# Altium schematic sheet Sheet1.SchDoc
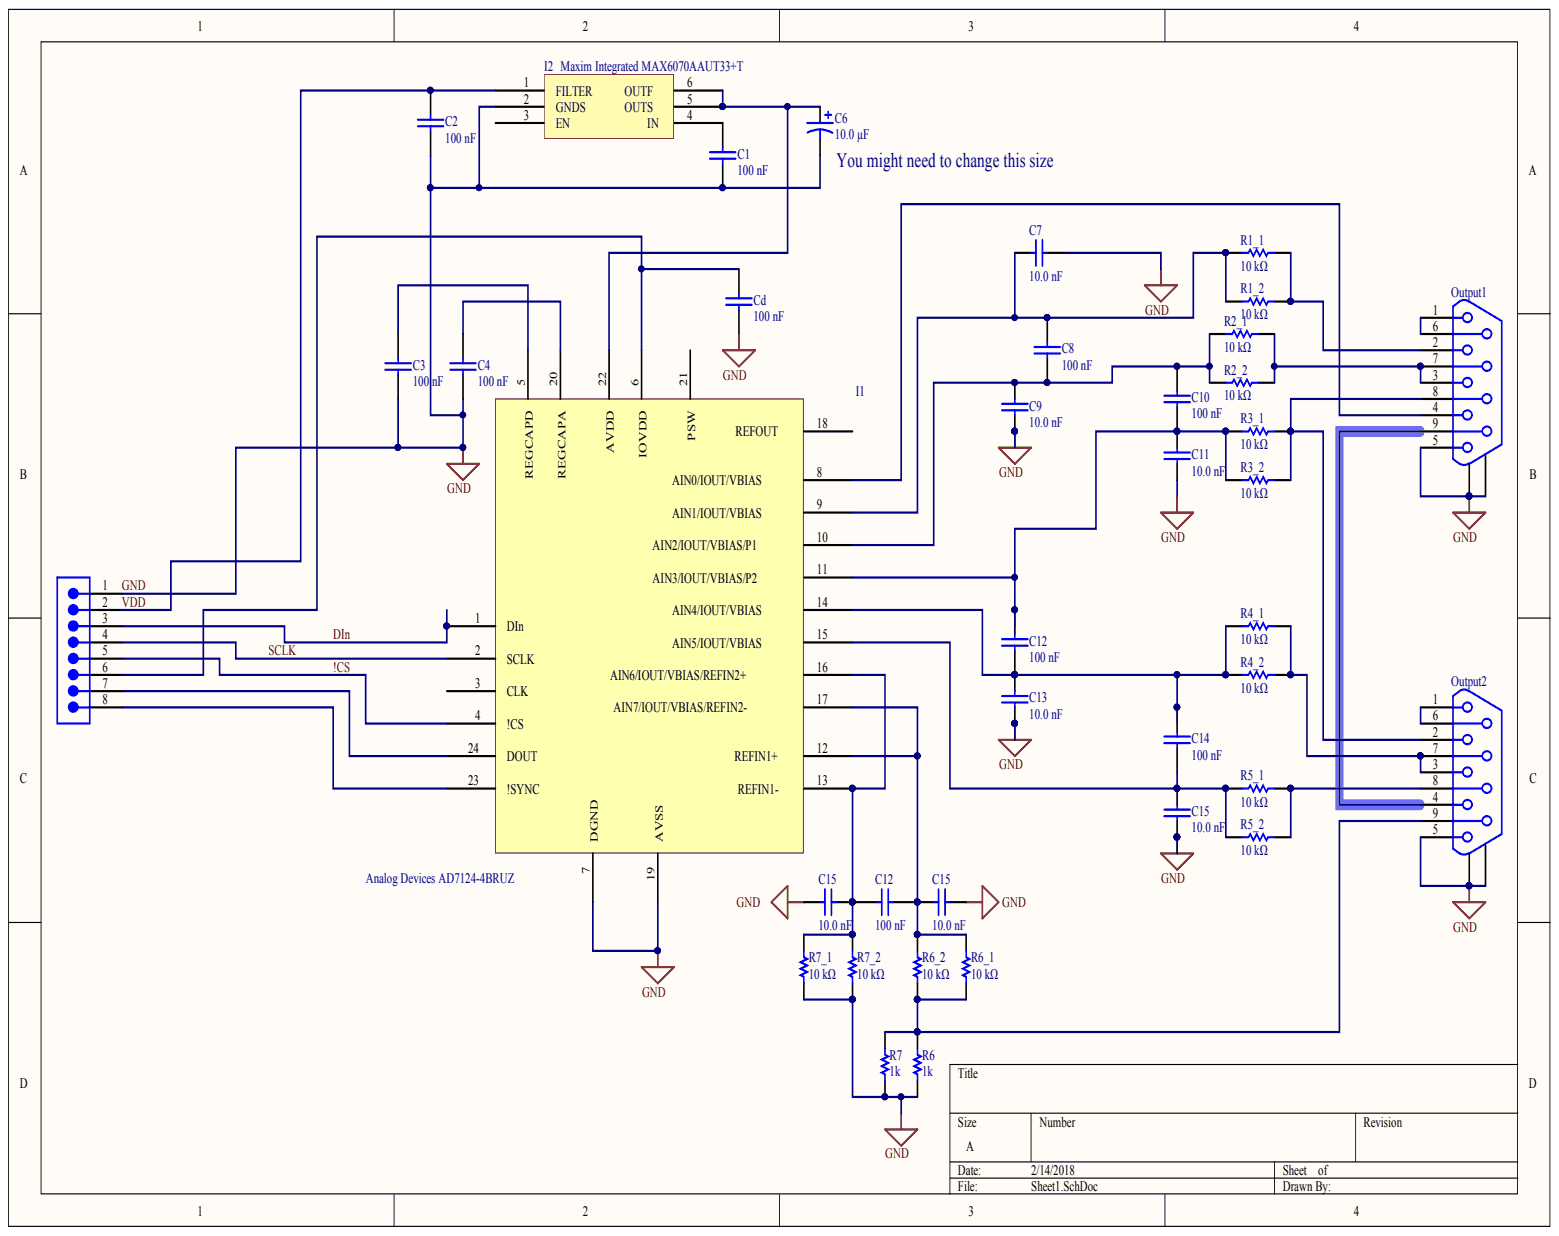
[diagram p0: Sheet1.SchDoc]

## Parts
C1  100 nF  [PCB-bgadz4xbubbd3co6jzoj-1]
C2  100 nF  [PCB-bgadz4xbubbd3co6jzoj-1]
C3  100 nF  [PCB-bgadz4xbubbd3co6jzoj-1]
C4  100 nF  [PCB-bgadz4xbubbd3co6jzoj-1]
C6  10.0 µF  [PCB-aekwh31ok7f3v0ct2zck-1]
C7  10.0 nF  [PCB-eb06anux58oi34v4cq7x-1]
C8  100 nF  [PCB-bgadz4xbubbd3co6jzoj-1]
C9  10.0 nF  [PCB-eb06anux58oi34v4cq7x-1]
C10  100 nF  [PCB-bgadz4xbubbd3co6jzoj-1]
C11  10.0 nF  [PCB-eb06anux58oi34v4cq7x-1]
C12  100 nF  [PCB-bgadz4xbubbd3co6jzoj-1]
C12  100 nF  [PCB-bgadz4xbubbd3co6jzoj-1]
C13  10.0 nF  [PCB-eb06anux58oi34v4cq7x-1]
C14  100 nF  [PCB-bgadz4xbubbd3co6jzoj-1]
C15  10.0 nF  [PCB-eb06anux58oi34v4cq7x-1]
C15  10.0 nF  [PCB-eb06anux58oi34v4cq7x-1]
C15  10.0 nF  [PCB-eb06anux58oi34v4cq7x-1]
Cd  100 nF  [PCB-bgadz4xbubbd3co6jzoj-1]
I1  Analog Devices AD7124-4BRUZ  [PCB-qd7qsdcczjsvw3y7jk0s-1]
I2  Maxim Integrated MAX6070AAUT33+T  Manufacturer Part Number: MAX6070AAUT33+T  [PCB-4t2mn98d640za1pnapfx-1]
Output1  Future Technology Devices International (FTDI) DB9-USB-M  [DB9_M_A]  (value not drawn)
Output2  Future Technology Devices International (FTDI) DB9-USB-M  [DB9_M_A]  (value not drawn)
P1  TE Connectivity 826629-8  [TECO-826629-8_V]  (value not drawn)
R1_1  10 kΩ  [RESC2013X60X35LL10T20]
R1_2  10 kΩ  [RESC2013X60X35LL10T20]
R2_1  10 kΩ  [RESC2013X60X35LL10T20]
R2_2  10 kΩ  [RESC2013X60X35LL10T20]
R3_1  10 kΩ  [RESC2013X60X35LL10T20]
R3_2  10 kΩ  [RESC2013X60X35LL10T20]
R4_1  10 kΩ  [RESC2013X60X35LL10T20]
R4_2  10 kΩ  [RESC2013X60X35LL10T20]
R5_1  10 kΩ  [RESC2013X60X35LL10T20]
R5_2  10 kΩ  [RESC2013X60X35LL10T20]
R6  1k  [PCB-1i9h9mz70gupttolrhkb-1]
R6_1  10 kΩ  [RESC2013X60X35LL10T20]
R6_2  10 kΩ  [RESC2013X60X35LL10T20]
R7  1k  [PCB-1i9h9mz70gupttolrhkb-1]
R7_1  10 kΩ  [RESC2013X60X35LL10T20]
R7_2  10 kΩ  [RESC2013X60X35LL10T20]

## Nets
!CS: I1.4(!CS) P1.5
DIN: I1.1(DIn) P1.3
GND: C1.1 C2.1 C3.1 C4.1 C6.2 C7.2 C9.1 C11.1 C13.1 C15.1 Cd.1 I1.7(DGND) I1.19(AVSS) I2.2(GNDS) Output1.0 Output1.5 Output2.0 Output2.5 P1.1 R6.1 R7.1 R7_1.1 R7_2.1
SCLK: I1.2(SCLK) P1.4
VDD: C2.2 I2.1(FILTER) P1.2
NetC1_2: C1.2 I2.4(IN)
NetC3_2: C3.2 I1.5(REGCAPD)
NetC4_2: C4.2 I1.20(REGCAPA)
NetC6_1: C6.1 I1.22(AVDD) I2.5(OUTS) I2.6(OUTF)
NetC7_1: C7.1 C8.2 I1.9 R1_1.1 R1_2.1
NetC8_1: C8.1 C9.2 C10.2 I1.10 R2_1.1 R2_2.1
NetC10_1: C10.1 C11.2 C12.2 I1.11 R3_1.1 R3_2.1
NetC12_1: C12.1 C13.2 C14.2 C15.2 I1.12(REFIN1+) I1.14 I1.17 R4_1.1 R4_2.1 R6_1.2 R6_2.2
NetC12_2: C12.2 C15.2 I1.13(REFIN1-) I1.16 R7_1.2 R7_2.2
NetC14_1: C14.1 C15.2 I1.15 R5_1.1 R5_2.1
NetCd_2: Cd.2 I1.6(IOVDD) P1.6
NetI1_8: I1.8 Output1.4
NetI1_23: I1.23(!SYNC) P1.8
NetI1_24: I1.24(DOUT) P1.7
NetOutput1_1: Output1.1 Output1.6
NetOutput1_2: Output1.2 R1_1.2 R1_2.2
NetOutput1_3: Output1.3 Output1.7 R2_1.2 R2_2.2
NetOutput1_8: Output1.8 Output2.2 R3_1.2 R3_2.2
NetOutput1_9: Output1.9 Output2.4
NetOutput2_1: Output2.1 Output2.6
NetOutput2_3: Output2.3 Output2.7 R4_1.2 R4_2.2
NetOutput2_8: Output2.8 R5_1.2 R5_2.2
NetOutput2_9: Output2.9 R6.2 R6_1.1 R6_2.1 R7.2

Not connected: I1 3(CLK) 18(REFOUT) 21(PSW); I2 3(EN)

## Library data of the parts
C1-C4 C8 C10 C12 C12 C14 Cd = CMP-437c8a7497fb9cbb-31: Ceramic capacitor SMD 0805 0.1 µF 50 V 10 % Yageo CC0805KRX7R9BB104 1 pc(s); Capacitance Tolerance: ±10%; Case/Package (SI): 2012; Dielectric Characteristic: X7R; Insulation Resistance: 100 GΩ; Lead-Free Status: Lead Free; Voltage Rating (DC): 50.0 V; Capacitance: 100 nF; Case/Package: 0805; Component Kind: Standard; Halogen Free Status: Halogen Free; Library Name: CommunityVault; Manufacturer Part Number: CC0805KRX7R9BB104; Mounting Style: Surface Mount; Number of Pins: 2; OctopartID: 437c8a7497fb9cbb; Octopart URL: http://octopart.com/cc0805krx7r9bb104-yageo-192993; Packaging: Cut Tape (CT), Tape & Reel (TR); REACH SVHC Compliance: No SVHC; RoHS: Compliant; Size-Height: 940 µm; Size-Length: 2.01 mm; Size-Width: 1.24 mm
C6 = CMP-5f7f942f151780b8-1: Capacitor; Alum Electrolytic; Cap 10 uF; Tol 20%; Vol-Rtg 16 VDC; SMT; 4x5.4; Cut Tape; Manufacturer Part Number: EEE-FC1C100R; Dielectric Material: Aluminum Electrolytic; Lead-Free Status: Lead Free; Lead Length: 1.80 mm; Voltage Rating (DC): 16.0 V; Capacitance: 10.0 µF; Capacitance Tolerance: ±20%; Component Kind: Standard; Library Name: CommunityVault; Lifecycle Status: Not Listed by Manufacturer; Mounting Style: Surface Mount; Number of Pins: 2; OctopartID: 5f7f942f151780b8; Octopart URL: http://octopart.com/eee-fc1c100r-panasonic-39481102; Packaging: Cut Tape (CT); REACH SVHC Compliance: No SVHC; RoHS: Compliant; Size-Diameter: 4.00 mm; Size-Height: 5.40 mm; Size-Length: 5.40 mm; Size-Width: 650 µm
C7 C9 C11 C13 C15 C15 C15 = CMP-123764-3: 0805 10 nF 50 V ±10 % Tolerance X7R SMT Multilayer Ceramic Capacitor; Manufacturer: Yaego; Manufacturer Part Number: CC0805KRX7R9BB103; Capacitance Tolerance: ±10%; Case/Package (SI): 2012; Insulation Resistance: 100 GΩ; Lead-Free Status: Lead Free; Voltage Rating (DC): 50.0 V; Capacitance: 10.0 nF; Case/Package: 0805; Component Kind: Standard; Dielectric Characteristic: X7R; Halogen Free Status: Halogen Free; Library Name: CommunityVault; Mounting Style: Surface Mount; Number of Pins: 2; OctopartID: 608ca984b437bf4b; Octopart URL: http://octopart.com/cc0805krx7r9bb103-yageo-192989; Packaging: Cut Tape (CT), Tape & Reel (TR); REACH SVHC Compliance: No SVHC; RoHS: Compliant; Size-Height: 940 µm; Size-Length: 2.01 mm; Size-Width: 1.24 mm
I1 = CMP-17c5dd5327acc802-2: 7-Channel Single ADC Delta-Sigma 24-bit Serial 24-Pin TSSOP Tube; Input Capacitance: 5.50 pF; Input Impedance: 3.00 TΩ; Number of Bits: 24; Sample Rate: 19.2 kHz; Supply Voltage (DC): 2.70 V (min); Component Kind: Standard; Library Name: CommunityVault; Lifecycle Status: Active; OctopartID: 17c5dd5327acc802; Octopart URL: http://octopart.com/ad7124-4bruz-analog+devices-60560655; RoHS: Compliant
I2 = CMP-7c77b4d0ceeca3b0-1: Low-Noise, High-Precision Series Voltage References; Lead-Free Status: Lead Free; Component Kind: Standard; Library Name: FavoritesLibrary; Lifecycle Status: Not Listed by Manufacturer; OctopartID: 7c77b4d0ceeca3b0; Octopart URL: http://octopart.com/max6070aaut33%2Bt-maxim+integrated-28153853; Packaging: Reel; RoHS: Compliant
Output1 Output2 = CMP-92b95b687ea34d7e-1: MODULE, CONVERTER, DB9 - USB, MALE; Accessory Type: Male DB9 Connector; SVHC: No SVHC (18-Jun-2010); Lead-Free Status: Lead Free; Supply Voltage (DC): 4.25 V (min); Component Kind: Standard; Library Name: CommunityVault; Manufacturer Part Number: DB9-USB-M; OctopartID: 92b95b687ea34d7e; Octopart Url: http://octopart.com/db9-usb-m-ftdi-14403964; REACH SVHC Compliance: No SVHC; RoHS: Compliant; Seller Landing  Url: https://octopart-clicks.com/click/track?country=US&ak=8157f166&sig=07b3f07&sid=5489&ppid=14403964&vpid=271173911&ct=offers
P1 = CMP-660753-1: Headers & Wire Housings 1X08 POS VERT AU; 3D Model: 826629-8.pdf; CiivaID: 660753; Connector Type: Header, Unshrouded, Breakaway; Dynamic Catalog: AMPMODU Mod II Series; Number of Positions: 8; Number of Positions Loaded: All; Other Names: 826629-8-NDA106753; Pitch: 0.100" (2.54mm); Category: Connectors, Interconnects; Ciiva URL: https://ciiva.com/part/826629-8-660753; Color: Green; Component Kind: Standard; Contact Finish: Gold; Contact Finish Thickness: 31.5µin (0.80µm); Contact Mating Length: 0.264" (6.70mm); Contact Type: Male Pin; Family: Rectangular Connectors - Headers, Male Pins; Library Name: CommunityVault; Manufacturer Part Number: 826629-8; Mounting Type: Through Hole; Number of Rows: 1; Packaging: Bulk; RoHS Information: 826629-8 Statement of Compliance; Series: AMPMODU Mod II; Standard Package: 504; Termination: Solder
R1_1 R1_2 R2_1 R2_2 R3_1 R3_2 R4_1 R4_2 R5_1 R5_2 R6_1 R6_2 R7_1 R7_2 = CMP-1932822-2: RES SMD 10K OHM 0.1% 1/8W 0805; Case/Package (SI): 2012; Lead-Free Status: Lead Free; Power Rating: 0.125 W; Voltage Rating (DC): 150.0 V; Case/Package: 0805; Component Kind: Standard; Library Name: CommunityVault; Mounting Style: Surface Mount; OctopartID: a5026c1a68aa7ac5; Octopart Url: https://octopart.com/rt0805bre0710kl-yageo-1239246; Resistance: 10 kΩ; Resistance Tolerance: ±0.1%; RoHS: Compliant; Size-Height: 0.0005 m; Size-Length: 0.002 m; Size-Width: 0.00125 m
R6 R7 = CMP-8944416cc8ea5683-3: Res 1k Ohm 1/4w 0.1% Axial; Component Kind: Standard; Library Name: CommunityVault
